# Altium schematic sheet RoBeast_Power_Linear.SchDoc(RoBeast_Power_Linear)
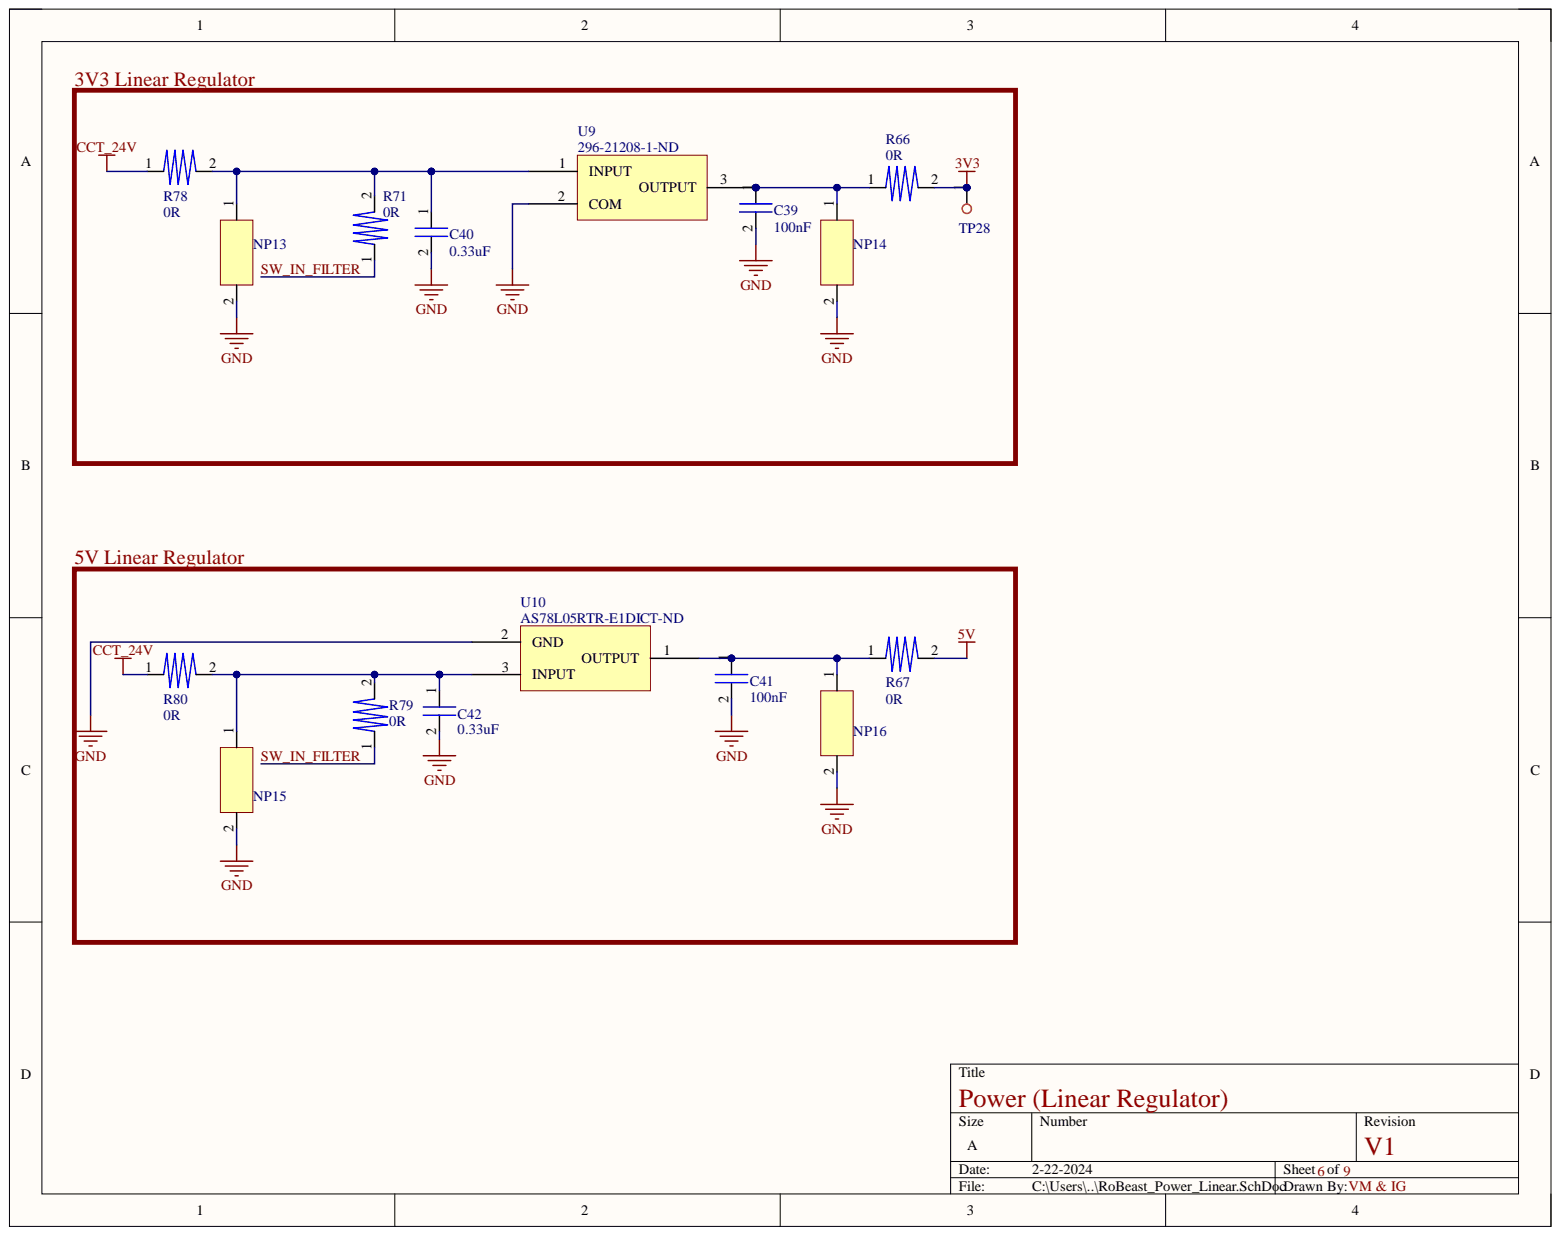
[diagram p0: RoBeast_Power_Linear.SchDoc]

## Parts
C39  100nF  [0805]
C40  0.33uF  [0805]
C41  100nF  [0805]
C42  0.33uF  [0805]
NP13  Not Populated  [0805]  (value not drawn)
NP14  Not Populated  [0805]  (value not drawn)
NP15  Not Populated  [0805]  (value not drawn)
NP16  Not Populated  [0805]  (value not drawn)
R66  0R  [0805]
R67  0R  [0805]
R71  0R  [0805]
R78  0R  [0805]
R79  0R  [0805]
R80  0R  [0805]
TP28  Test Point  [Test Point]  (value not drawn)
U9  Supplier Part Number 1: 296-21208-1-ND  [UA78M33CKVURG3]  (hidden comment: Fixed 3V3 Voltage Regulator)
U10  Supplier Part Number 1: AS78L05RTR-E1DICT-ND  [AS78L05RTR-E1]  (hidden comment: Fixed 5V Linear Regulator)

## Nets
3V3: R66.2 TP28.1
5V: R67.2
CCT_24V: R78.1 R80.1
GND: C39.2 C40.2 C41.2 C42.2 NP13.2 NP14.2 NP15.2 NP16.2 U9.2 U10.2
SW_IN_FILTER: R71.1 R79.1
NetC39_1: C39.1 NP14.1 R66.1 U9.3
NetC40_1: C40.1 NP13.1 R71.2 R78.2 U9.1
NetC41_1: C41.1 NP16.1 R67.1 U10.1
NetC42_1: C42.1 NP15.1 R79.2 R80.2 U10.3

## Library data of the parts
C39 C41 = C0805C104J5RAC7800: Ceramic Capacitor, Multilayer, Ceramic, 50V, 5% +Tol, 5% -Tol, X7R, 15% TC, 0.1uF, Surface Mount, 0805; Manufacturer: KEMET; Manufacturer Part Number: C0805C104J5RACTU; Multilayer: Yes; Capacitance: 100nF; Capacitor Type: Ceramic; Category: Ceramic Capacitors; Component Kind: Standard; ComponentLink1Description: http://capacitoredge.kemet.com:80/capedge2/intellispec.jsp?pn=C0805C104J5RACTU&displayPN=C0805C104J5RACTU&src-supplier=IHS+Markit; ComponentLink1URL: http://capacitoredge.kemet.com:80/capedge2/intellispec.jsp?pn=C0805C104J5RACTU&displayPN=C0805C104J5RACTU&src-supplier=IHS+Markit; ComponentLink2Description: https://datasheet.ciiva.com/7842/1732721-7842179.pdf?src-supplier=Element14; ComponentLink2URL: https://datasheet.ciiva.com/7842/1732721-7842179.pdf?src-supplier=Element14; ComponentLink3Description: https://datasheet.ciiva.com/28682/1851736-28682223.pdf?src-supplier=Element14; ComponentLink3URL: https://datasheet.ciiva.com/28682/1851736-28682223.pdf?src-supplier=Element14; ComponentLink4Description: https://datasheet.ciiva.com/7258/1828800-7258413.pdf?src-supplier=Newark; ComponentLink4URL: https://datasheet.ciiva.com/7258/1828800-7258413.pdf?src-supplier=Newark; ComponentLink5Description: https://datasheet.ciiva.com/14589/70095383-14589015.pdf?src-supplier=Allied+Electronics; ComponentLink5URL: https://datasheet.ciiva.com/14589/70095383-14589015.pdf?src-supplier=Allied+Electronics; ComponentLink6Description: https://datasheet.ciiva.com/5417/kem-c1002-x7r-smd-5417352.pdf?src-supplier=Mouser; ComponentLink6URL: https://datasheet.ciiva.com/5417/kem-c1002-x7r-smd-5417352.pdf?src-supplier=Mouser; ComponentLink7Description: https://datasheet.ciiva.com/39122/docfinderpartnumberc0402c102k5ractu-39122924.pdf?src-supplier=Onlinecomponents.com; ComponentLink7URL: https://datasheet.ciiva.com/39122/docfinderpartnumberc0402c102k5ractu-39122924.pdf?src-supplier=Onlinecomponents.com; Dielectric Material: Ceramic; JESD-609 Code: e3; Max Operating Temperature: 125°C; Min Operating Temperature: -55°C; Mount: Surface Mount; Negative Tolerance: 5%; Number of Pins: 2; Package Shape: Rectangular; Packaging: Tape and Reel; Positive Tolerance: 5%; Pricing 1: 1=0.18, 10=0.122, 50=0.0732, 100=0.0576, 500=0.04252, 1000=0.03519 (USD); Rated DC Voltage (URdc): 50V; Reach SVHC Compliant: Yes; RoHS: Yes; RoHS Compliant: Yes; Size Code: 0805; Stock 1: 605061; Supplier 1: Digikey; Supplier Part Number 1: 399-C0805C104J5RAC7800CT-ND; Temperature Characteristics Code: X7R; Terminal Finish: Nickel; Termination: Wraparound; Library Name: RoBeast_Schematic_Library.SchLib
C40 C42 = C0805C334K5RAC7800: Ceramic Capacitor, Multilayer, Ceramic, 50V, 10% +Tol, 10% -Tol, X7R, 15% TC, 0.33uF, Surface Mount, 0805; Manufacturer: KEMET; Manufacturer Part Number: C0805C334K5RACTU; Capacitance: 330nF; Multilayer: Yes; Capacitor Type: Ceramic; Category: Ceramic Capacitors; Component Kind: Standard; ComponentLink1Description: http://capacitoredge.kemet.com:80/capedge2/DataSheet/Datasheet-C0805C334K5RAC7800.pdf?pn=C0805C334K5RAC7800&src-supplier=IHS+Markit; ComponentLink1URL: http://capacitoredge.kemet.com:80/capedge2/DataSheet/Datasheet-C0805C334K5RAC7800.pdf?pn=C0805C334K5RAC7800&src-supplier=IHS+Markit; ComponentLink2Description: https://datasheet.ciiva.com/28608/1851736-28608437.pdf?src-supplier=Element14; ComponentLink2URL: https://datasheet.ciiva.com/28608/1851736-28608437.pdf?src-supplier=Element14; ComponentLink3Description: https://datasheet.ciiva.com/28696/1851736-28696038.pdf?src-supplier=Element14; ComponentLink3URL: https://datasheet.ciiva.com/28696/1851736-28696038.pdf?src-supplier=Element14; ComponentLink4Description: https://datasheet.ciiva.com/18193/getdatasheetpartid-385729-18193783.pdf?src-supplier=Verical; ComponentLink4URL: https://datasheet.ciiva.com/18193/getdatasheetpartid-385729-18193783.pdf?src-supplier=Verical; ComponentLink5Description: https://datasheet.ciiva.com/18193/getdatasheetpartid-93202-18193786.pdf?src-supplier=Verical; ComponentLink5URL: https://datasheet.ciiva.com/18193/getdatasheetpartid-93202-18193786.pdf?src-supplier=Verical; Dielectric Material: Ceramic; JESD-609 Code: e3; Manufacturer Series: C0805C334K5RACTU; Max Operating Temperature: 125°C; Min Operating Temperature: -55°C; Mount: Surface Mount; Negative Tolerance: 10%; Number of Pins: 2; Package Shape: Rectangular; Packaging: Tape and Reel; Positive Tolerance: 10%; Pricing 1: 1=0.16, 10=0.111, 50=0.0662, 100=0.0521, 500=0.0385, 1000=0.03188 (USD); Rated DC Voltage (URdc): 50V; Reach SVHC Compliant: Yes; RoHS: Yes; RoHS Compliant: Yes; Size Code: 0805; Stock 1: 50949; Supplier 1: Digikey; Supplier Part Number 1: 399-C0805C334K5RAC7800CT-ND; Temperature Characteristics Code: X7R; Terminal Finish: Nickel; Termination: Wraparound; Library Name: RoBeast_Schematic_Library.SchLib
NP13-NP16 = NP: Component Kind: Standard; Library Name: RoBeast_Schematic_Library.SchLib
R66 R67 R71 R78-R80 = RMCF0805ZT0R00: Fixed Resistor, Metal Glaze/thick Film, 0.125W, 0ohm, Surface Mount, 0805; Manufacturer: Stackpole Electronics; Package Height: 0.5mm; Package Length: 2mm; Package Width: 1.25mm; Rated Power Dissipation (P): 125mW; Rated Temperature: 70°C; Reference Standard: AEC-Q200; Working Voltage: 150V; Category: Chip SMD Resistors; Component Kind: Standard; ComponentLink1Description: https://datasheet.ciiva.com:443/pdfs/VipMasterIC/IC/SEIE/SEIE-S-A0007077258/SEIE-S-A0007077258-1.pdf?src-supplier=IHS+Markit; ComponentLink1URL: https://datasheet.ciiva.com:443/pdfs/VipMasterIC/IC/SEIE/SEIE-S-A0007077258/SEIE-S-A0007077258-1.pdf?src-supplier=IHS+Markit; ComponentLink2Description: https://datasheet.ciiva.com/15193/getdatasheetpartid-360417-15193658.pdf?src-supplier=Verical; ComponentLink2URL: https://datasheet.ciiva.com/15193/getdatasheetpartid-360417-15193658.pdf?src-supplier=Verical; Construction: Rectangular; JESD-609 Code: e3; Manufacturer Part Number: RMCF0805ZT0R00; Max Operating Temperature: 155°C; Min Operating Temperature: -55°C; Mount: Surface Mount; Number of Pins: 2; Package Shape: Rectangular; Package Style: SMTMeter; Packaging: Tape and Reel; Pricing 1: 1=0.1, 10=0.017, 25=0.0128, 50=0.0096, 100=0.0068, 250=0.00544, 500=0.00436, 1000=0.00321 (USD); Reach SVHC Compliant: Yes; Resistance: 0R; Resistor Type: FIXED RESISTOR; RoHS: Yes; RoHS Compliant: Yes; Size Code: 0805; Stock 1: 1154169; Supplier 1: Digikey; Supplier Part Number 1: RMCF0805ZT0R00CT-ND; Technology: METAL GLAZE/THICK FILM; Terminal Finish: Nickel; Termination: Wraparound; Library Name: RoBeast_Schematic_Library.SchLib
U9 = UA78M33CKVURG3: Fixed Positive Standard Regulator, 3.3VBIPolar, PSSO2; Manufacturer: Texas Instruments; Dropout Voltage1-Nom: 2V; Input Voltage Absolute-Max: 35V; Line Regulation-Max: 0.1%; Max Input Voltage: 25V; Min Input Voltage: 5.3V; Number of Functions: 1; Output Current1-Max: 500mA; Output Voltage1-Max: 3.5V; Output Voltage1-Min: 3.1V; Output Voltage1-Nom: 3.3V; Package Body Material: Plastic; Package Equivalence Code: SMSIP3H,.38,90TB; Qualification Status: Not Qualified; Regulator Type: FIXED POSITIVE SINGLE OUTPUT STANDARD REGULATOR; Voltage Tolerance-Max: 6%; Adjustability: FIXED; Category: Voltage Regulators - Linear; Component Kind: Standard; ComponentLink1Description: https://www.ti.com:443/lit/ds/symlink/ua78m.pdf?src-supplier=IHS+Markit; ComponentLink1URL: https://www.ti.com:443/lit/ds/symlink/ua78m.pdf?src-supplier=IHS+Markit; ComponentLink2Description: https://datasheet.ciiva.com/1512/1882046-1512317.pdf?src-supplier=Element14; ComponentLink2URL: https://datasheet.ciiva.com/1512/1882046-1512317.pdf?src-supplier=Element14; ComponentLink3Description: https://datasheet.ciiva.com/46394/1882046-46394975.pdf?src-supplier=Element14; ComponentLink3URL: https://datasheet.ciiva.com/46394/1882046-46394975.pdf?src-supplier=Element14; ComponentLink4Description: https://datasheet.ciiva.com/2042/ua78m05-2042378.pdf?src-supplier=Digikey; ComponentLink4URL: https://datasheet.ciiva.com/2042/ua78m05-2042378.pdf?src-supplier=Digikey; ComponentLink5Description: https://datasheet.ciiva.com/2042/ua78m05-2042377.pdf?src-supplier=Digikey; ComponentLink5URL: https://datasheet.ciiva.com/2042/ua78m05-2042377.pdf?src-supplier=Digikey; ComponentLink6Description: https://datasheet.ciiva.com/2042/ua78m05-2042376.pdf?src-supplier=Digikey; ComponentLink6URL: https://datasheet.ciiva.com/2042/ua78m05-2042376.pdf?src-supplier=Digikey; Height - Seated (Max): 2.52mm; JESD-30 Code: R-PSSO-G2; JESD-609 Code: e3; Length: 6.6mm; Load Regulation-Max: 0.1%; Manufacturer Part Number: UA78M33CKVURG3; Moisture Sensitivity Level: 3; Number of Outputs: 1; Number of Pins: 2; Operating Temperature, TJ-Max: 125°C; Operating Temperature, TJ-Min: 0°C; Package Code: TO-252; Package Shape: Rectangular; Package Style: SMALL OUTLINE, HEAT SINK/SLUGMeter; Packaging: Tape and Reel; Peak Reflow Temperature (Cel): 260°C; Pricing 1: 1=0.59, 10=0.517, 25=0.4852, 100=0.3961, 250=0.36792, 500=0.31312, 1000=0.2505 (USD); Reach SVHC Compliant: Yes; RoHS: Yes; RoHS Compliant: Yes; Stock 1: 58004; Supplier 1: Digikey; Surface Mount: Yes; Technology: BIPOLAR; Terminal Finish: Tin; Terminal Form: Gull Wing; Terminal Pitch: 2.29mm; Terminal Position: SINGLE; Width: 6.095mm; Library Name: RoBeast_Schematic_Library.SchLib
U10 = AS78L05RTR-E1: Fixed Positive Standard Regulator, 5VBIPolar; Manufacturer: Diodes; Dropout Voltage1-Nom: 1.8V; Input Voltage Absolute-Max: 36V; Line Regulation-Max: 0.15%; Load Regulation-Max: 0.06%; Number of Outputs: 1; Output Current1-Max: 100mA; Package Body Material: Plastic; Package Equivalence Code: TO-243; Qualification Status: Not Qualified; Regulator Type: FIXED POSITIVE SINGLE OUTPUT STANDARD REGULATOR; Voltage Tolerance-Max: 5%; Adjustability: FIXED; Category: Voltage Regulators - Linear; Component Kind: Standard; ComponentLink1Description: https://datasheet.ciiva.com:443/pdfs/VipMasterIC/IC/DIOD/DIODS20236/DIODS20236-1.pdf?src-supplier=IHS+Markit; ComponentLink1URL: https://datasheet.ciiva.com:443/pdfs/VipMasterIC/IC/DIOD/DIODS20236/DIODS20236-1.pdf?src-supplier=IHS+Markit; ComponentLink2Description: https://datasheet.ciiva.com/30016/as78lxx-30016635.pdf?src-supplier=Avnet; ComponentLink2URL: https://datasheet.ciiva.com/30016/as78lxx-30016635.pdf?src-supplier=Avnet; JESD-609 Code: e3; Manufacturer Part Number: AS78L05RTR-E1; Moisture Sensitivity Level: 3; Number of Pins: 3; Operating Temperature, TJ-Max: 125°C; Operating Temperature, TJ-Min: -40°C; Output Voltage1-Nom: 5V; Packaging: Tape and Reel; Pricing 1: 1=0.39, 10=0.291, 25=0.2628, 100=0.1815, 250=0.15288, 500=0.1242 (USD); Reach SVHC Compliant: Yes; RoHS: Yes; RoHS Compliant: Yes; Stock 1: 132070; Supplier 1: Digikey; Surface Mount: Yes; Technology: BIPOLAR; Terminal Finish: Tin; Library Name: RoBeast_Schematic_Library.SchLib
TP28 = Test Point: Component Kind: Standard; Library Name: RoBeast_Schematic_Library.SchLib
